# Altium schematic sheet Power.SchDoc
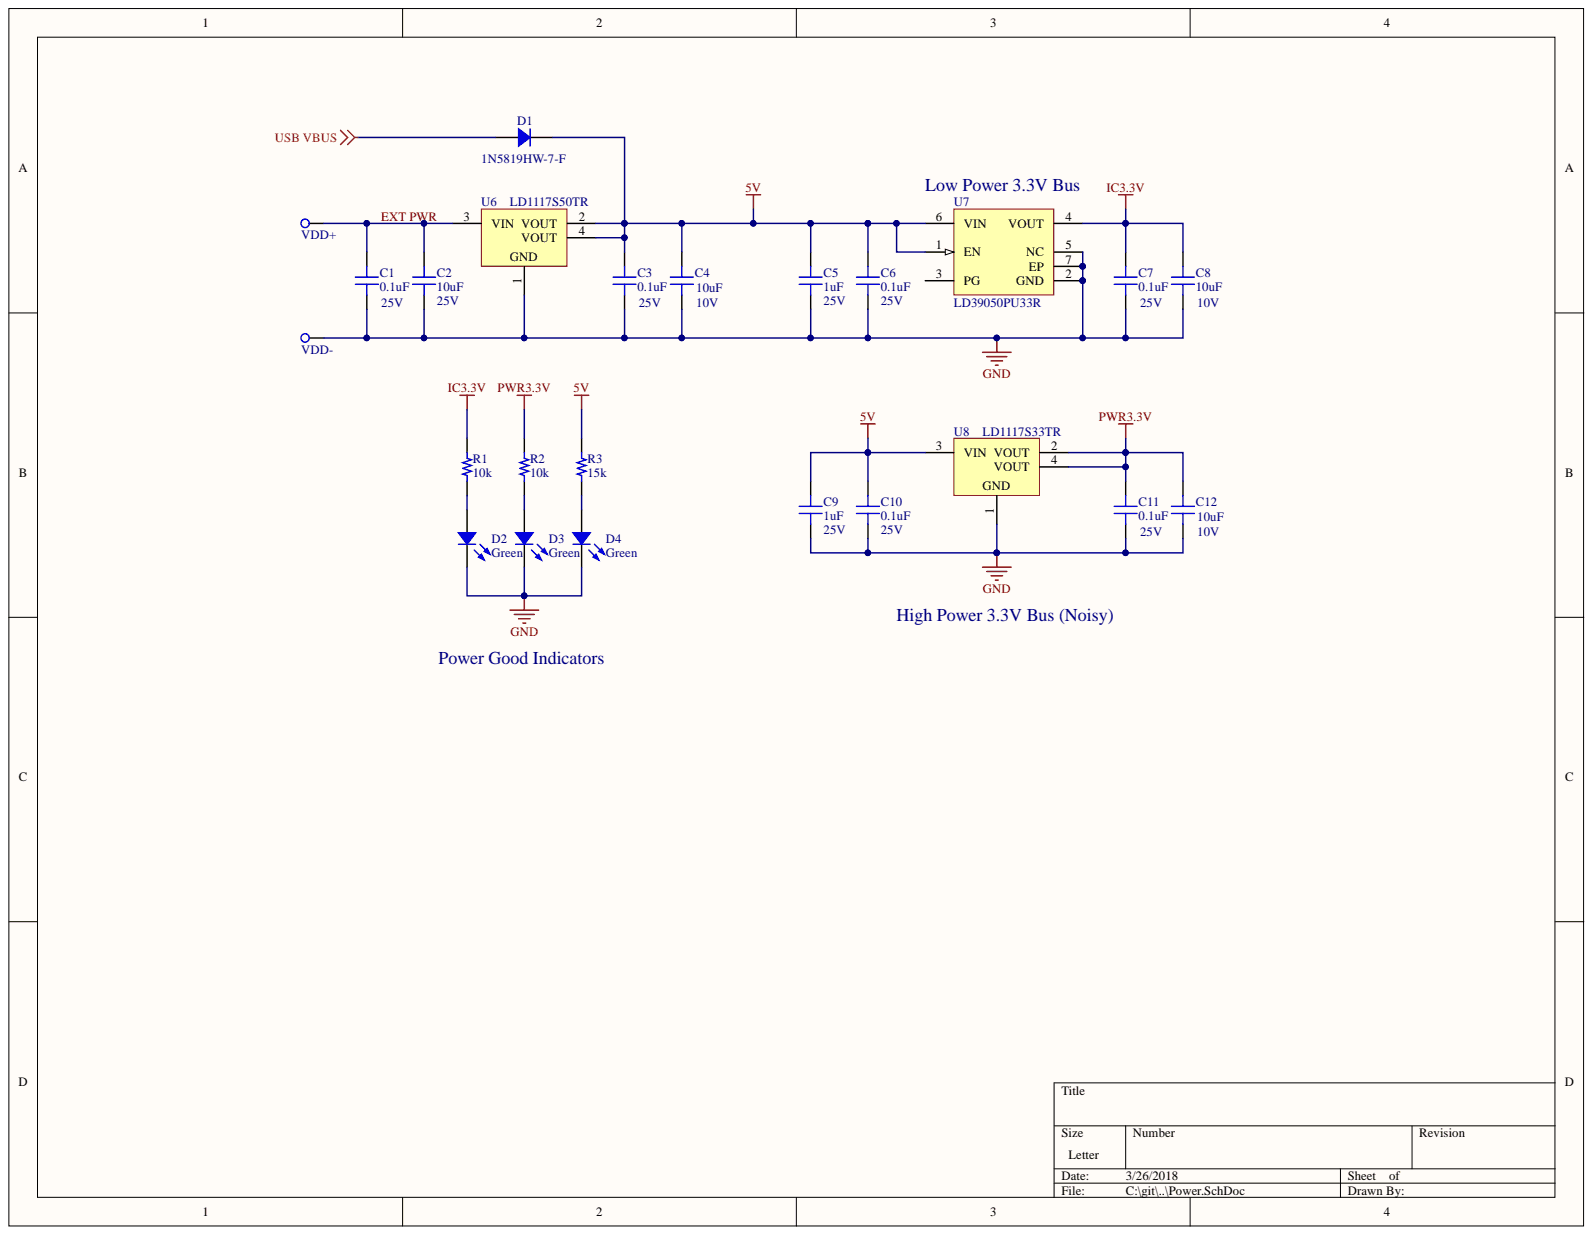
[diagram p0: Power.SchDoc]

## Parts
C1  0.1uF  Voltage: 25V  [RES 0805/2012]
C2  10uF  Voltage: 25V  [CAP 0805/2012]
C3  0.1uF  Voltage: 25V  [RES 0805/2012]
C4  10uF  Voltage: 10V  [CAP 0805/2012]
C5  1uF  Voltage: 25V  [CAP 0805/2012]
C6  0.1uF  Voltage: 25V  [RES 0805/2012]
C7  0.1uF  Voltage: 25V  [RES 0805/2012]
C8  10uF  Voltage: 10V  [CAP 0805/2012]
C9  1uF  Voltage: 25V  [CAP 0805/2012]
C10  0.1uF  Voltage: 25V  [RES 0805/2012]
C11  0.1uF  Voltage: 25V  [RES 0805/2012]
C12  10uF  Voltage: 10V  [CAP 0805/2012]
D1  1N5819HW-7-F  [SOD-123]
D2  Green  [LED 0603/1608 GREEN]
D3  Green  [LED 0603/1608 GREEN]
D4  Green  [LED 0603/1608 GREEN]
R1  10k  [RES 0603/1608]
R2  10k  [RES 0603/1608]
R3  15k  [RES 0603/1608]
U6  LD1117S50TR  [SOT-223_N]
U7  LD39050PU33R  [DFN6D_N]
U8  LD1117S33TR  [SOT-223_N]
VDD+  RCT-0C  [TE TP 0805]  (value not drawn)
VDD-  RCT-0C  [TE TP 0805]  (value not drawn)

## Nets
5V: C3.2 C4.2 C5.2 C6.2 C9.2 C10.2 D1.2 R3.2 U6.2(VOUT) U6.4(VOUT) U7.1(EN) U7.6(VIN) U8.3(VIN)
GND: C1.1 C2.1 C3.1 C4.1 C5.1 C6.1 C7.1 C8.1 C9.1 C10.1 C11.1 C12.1 D2.2 D3.2 D4.2 U6.1 U7.2(GND) U7.5(NC) U7.7(EP) U8.1 VDD-.1
IC3.3V: C7.2 C8.2 R1.2 U7.4(VOUT)
PWR3.3V: C11.2 C12.2 R2.2 U8.2(VOUT) U8.4(VOUT)
USB VBUS: D1.1
EXT PWR: C1.2 C2.2 U6.3(VIN) VDD+.1
NetD2_1: D2.1 R1.1
NetD3_1: D3.1 R2.1
NetD4_1: D4.1 R3.1

Not connected: U7 3(PG)

## Library data of the parts
C1 C3 C6 C7 C10 C11 = C2012X7R1H104K085AA: CAP CER 0.1UF 50V X7R 0805; Manufacturer: TDK Corporation; Database Table Name: Capacitor - Ceramic; TemperatureRange: -55C ~ 125C; Tolerance: 10%; Component Kind: Standard; ComponentLink1Description: Datasheet; ComponentLink1URL: https://product.tdk.com/info/en/catalog/datasheets/mlcc_commercial_general_en.pdf; ComponentLink2Description: Digi-Key listing; ComponentLink2URL: http://digikey.com/product-detail/en/C2012X7R1H104K085AA/445-7534-2-ND/2732969; Device Package: 0805 (2012 Metric); Library Name: Celestial Library Database.DbLib; PartId: 4390; Part Number: C2012X7R1H104K085AA; Price: 0.0182; Supplier 1: Digi-Key; Supplier Part Number 1: 445-7534-2-ND; TemperatureCoefficient: X7R; Type: -; Value: 0.1uF
C2 = C2012X5R1E106K125AB: CAP CER 10UF 25V X5R 0805; Manufacturer: TDK Corporation; Database Table Name: Capacitor - Ceramic; TemperatureRange: -55C ~ 85C; Tolerance: 10%; Component Kind: Standard; ComponentLink1Description: Datasheet; ComponentLink1URL: https://product.tdk.com/info/en/catalog/datasheets/mlcc_commercial_general_en.pdf; ComponentLink2Description: Digi-Key listing; ComponentLink2URL: http://digikey.com/product-detail/en/C2012X5R1E106K125AB/445-5984-2-ND/2443462; Device Package: 0805 (2012 Metric); Library Name: Celestial Library Database.DbLib; PartId: 4660; Part Number: C2012X5R1E106K125AB; Price: 0.1035; Supplier 1: Digi-Key; Supplier Part Number 1: 445-5984-2-ND; TemperatureCoefficient: X5R; Type: -; Value: 10uF
C4 C8 C12 = C2012X5R0J106K125AB: CAP CER 10UF 6.3V X5R 0805; Manufacturer: TDK Corporation; Database Table Name: Capacitor - Ceramic; TemperatureRange: -55C ~ 85C; Tolerance: 10%; Component Kind: Standard; ComponentLink1Description: Datasheet; ComponentLink1URL: https://product.tdk.com/info/en/catalog/datasheets/mlcc_commercial_general_en.pdf; ComponentLink2Description: Digi-Key listing; ComponentLink2URL: http://digikey.com/product-detail/en/C2012X5R0J106K125AB/445-4113-2-ND/1975460; Device Package: 0805 (2012 Metric); Library Name: Celestial Library Database.DbLib; PartId: 4503; Part Number: C2012X5R0J106K125AB; Price: 0.0486; Supplier 1: Digi-Key; Supplier Part Number 1: 445-4113-2-ND; TemperatureCoefficient: X5R; Type: -; Value: 10uF
C5 C9 = C2012X7R1E105K125AB: CAP CER 1UF 25V X7R 0805; Manufacturer: TDK Corporation; Database Table Name: Capacitor - Ceramic; TemperatureRange: -55C ~ 125C; Tolerance: 10%; Component Kind: Standard; ComponentLink1Description: Datasheet; ComponentLink1URL: https://product.tdk.com/info/en/catalog/datasheets/mlcc_commercial_general_en.pdf; ComponentLink2Description: Digi-Key listing; ComponentLink2URL: http://digikey.com/product-detail/en/C2012X7R1E105K125AB/445-1354-2-ND/513887; Device Package: 0805 (2012 Metric); Library Name: Celestial Library Database.DbLib; PartId: 4426; Part Number: C2012X7R1E105K125AB; Price: 0.0335; Supplier 1: Digi-Key; Supplier Part Number 1: 445-1354-2-ND; TemperatureCoefficient: X7R; Type: -; Value: 1uF
D1 = 1N5819HW-7-F: DIODE SCHOTTKY 40V 1A SOD123; Manufacturer: Diodes Incorporated; Current Reverse Leakage @ Vr: 1mA @ 40V; Database Table Name: Diode - Rectifier; Voltage Forward (Vf): 450mV @ 1A; Component Kind: Standard; ComponentLink1Description: Datasheet; ComponentLink1URL: http://www.diodes.com/_files/datasheets/ds30217.pdf; ComponentLink2Description: Digi-Key Listing; ComponentLink2URL: https://www.digikey.com/product-detail/en/diodes-incorporated/1N5819HW-7-F/1N5819HW-FDITR-ND/814970; Current Rectified: 1A; Device Package: SOD-123; Junction Operating Temperature: -65C ~ 125C; Library Name: Celestial Library Database.DbLib; PartId: 14604; Part Number: 1N5819HW-7-F; Price: 0.08; Supplier 1: Digi-Key; Supplier Part Number 1: 1N5819HW-FDITR-ND; Type: Schottky; Voltage DC Reverse (Vr): 40V
D2-D4 = LTST-C191KGKT: LED GREEN CLEAR 0603 SMD; Manufacturer: Lite-On Inc.; Current (Test): 20mA; Database Table Name: Chip LED; Millicandela: 35mcd; Type: Surface Mount; Viewing Angle: 130; Voltage Forward: 2V; Colour: Green; Component Kind: Standard; ComponentLink1Description: Datasheet; ComponentLink1URL: http://optoelectronics.liteon.com/upload/download/DS22-2000-228/S_110_LTST-C191KGKT.pdf; ComponentLink2Description: Digi-Key Listing; ComponentLink2URL: http://www.digikey.ca/product-detail/en/LTST-C191KGKT/160-1446-2-ND/386835; Device Package: 0603 (1608 Metric); Lens Transparency: Clear; Library Name: Celestial Library Database.DbLib; PartId: 7051; Part Number: LTST-C191KGKT; Price: 0.0521; Supplier 1: Digi-Key; Supplier Part Number 1: 160-1446-2-ND; Value: Green
R1-R3 = ERJ-3EKF1002V: RES SMD 10K OHM 1% 1/10W 0603; Manufacturer: Panasonic Electronic Components; Database Table Name: Resistor - Chip; PowerRating: 0.1W, 1/10W; TemperatureCoefficient: 100ppm/C; TemperatureRange: -55C ~ 155C; Component Kind: Standard; ComponentLink1Description: Datasheet; ComponentLink1URL: http://industrial.panasonic.com/www-cgi/jvcr13pz.cgi?E+PZ+3+AOA0002+ERJ3EKF1002V+7+WW; ComponentLink2Description: Digi-Key listing; ComponentLink2URL: http://digikey.com/product-detail/en/ERJ-3EKF1002V/P10.0KHTR-ND/196066; Device Package: 0603 (1608 Metric); Library Name: Celestial Library Database.DbLib; PartId: 886; Part Number: ERJ-3EKF1002V; Price: 0.0038; Supplier 1: Digi-Key; Supplier Part Number 1: P10.0KHTR-ND; Tolerance: 1%; Type: Thick Film
  R1 R2: Value: 10k
  R3: Value: 15k
U6 = LD1117S50TR: Fixed Low Drop Positive Voltage Regulator, 5V, 4-Pin SOT-223, Tape and Reel; Component Kind: Standard; ComponentLink1Description: Manufacturer URL; ComponentLink1URL: http://www.st.com; ComponentLink2Description: Datasheet; ComponentLink2URL: http://www.st.com/internet/com/TECHNICAL_RESOURCES/TECHNICAL_LITERATURE/DATASHEET/CD00000544.pdf; DatasheetVersion: Nov-2011; Library Name: STMicroelectronics Power Management Linear Regulator.IntLib; PackageDescription: 4-Pin SOT-223 Package, 6.5 x 3.5 mm Body, 2.3 mm Pitch, 7 mm Lead Span; PackageReference: SOT-223; PackageVersion: 2010; PartNumber: LD1117S50TR
U7 = LD39050PU33R: 500 mA Low Quiescent Current Low Noise Voltage Regulator, 3.3V, 6-Pin VDFDPN, Tape and Reel; Component Kind: Standard; ComponentLink1Description: Manufacturer URL; ComponentLink1URL: http://www.st.com; ComponentLink2Description: Datasheet; ComponentLink2URL: http://www.st.com/internet/com/TECHNICAL_RESOURCES/TECHNICAL_LITERATURE/DATASHEET/CD00227527.pdf; DatasheetVersion: Mar-2009; Library Name: STMicroelectronics Power Management Linear Regulator.IntLib; PackageDescription: 6-Pin Plastic, Dual LLP, 3 x 3 mm Body, 0.95 mm Pitch, Exposed Pad; PackageReference: DFN6D; PackageVersion: 2008; PartNumber: LD39050PU33R
U8 = LD1117S33TR: Fixed Low Drop Positive Voltage Regulator, 3.3V, 4-Pin SOT-223, Tape and Reel; Component Kind: Standard; ComponentLink1Description: Manufacturer URL; ComponentLink1URL: http://www.st.com; ComponentLink2Description: Datasheet; ComponentLink2URL: http://www.st.com/internet/com/TECHNICAL_RESOURCES/TECHNICAL_LITERATURE/DATASHEET/CD00000544.pdf; DatasheetVersion: Nov-2011; Library Name: STMicroelectronics Power Management Linear Regulator.IntLib; PackageDescription: 4-Pin SOT-223 Package, 6.5 x 3.5 mm Body, 2.3 mm Pitch, 7 mm Lead Span; PackageReference: SOT-223; PackageVersion: 2010; PartNumber: LD1117S33TR
VDD+ VDD- = RCT-0C: 0805 PROBE PAD; Manufacturer: TE Connectivity AMP Connectors; Database Table Name: Test Point; Component Kind: Standard; ComponentLink1Description: Datasheet; ComponentLink1URL: http://www.te.com/commerce/DocumentDelivery/DDEController?Action=srchrtrv&DocNm=1773266&DocType=DS&DocLang=English; ComponentLink2Description: Digi-Key Listing; ComponentLink2URL: http://www.digikey.com/product-detail/en/te-connectivity-amp-connectors/RCT-0C/A106144CT-ND/3477801; Library Name: Celestial Library Database.DbLib; Mounting Type: Surface Mount; PartId: 15704; Part Number: RCT-0C; Price: 0.14; Supplier 1: Digi-Key; Supplier Part Number 1: A106144CT-ND; Type: PC Test Point
